# Altium schematic sheet controller_peripherals.SchDoc(controller_peripherals)
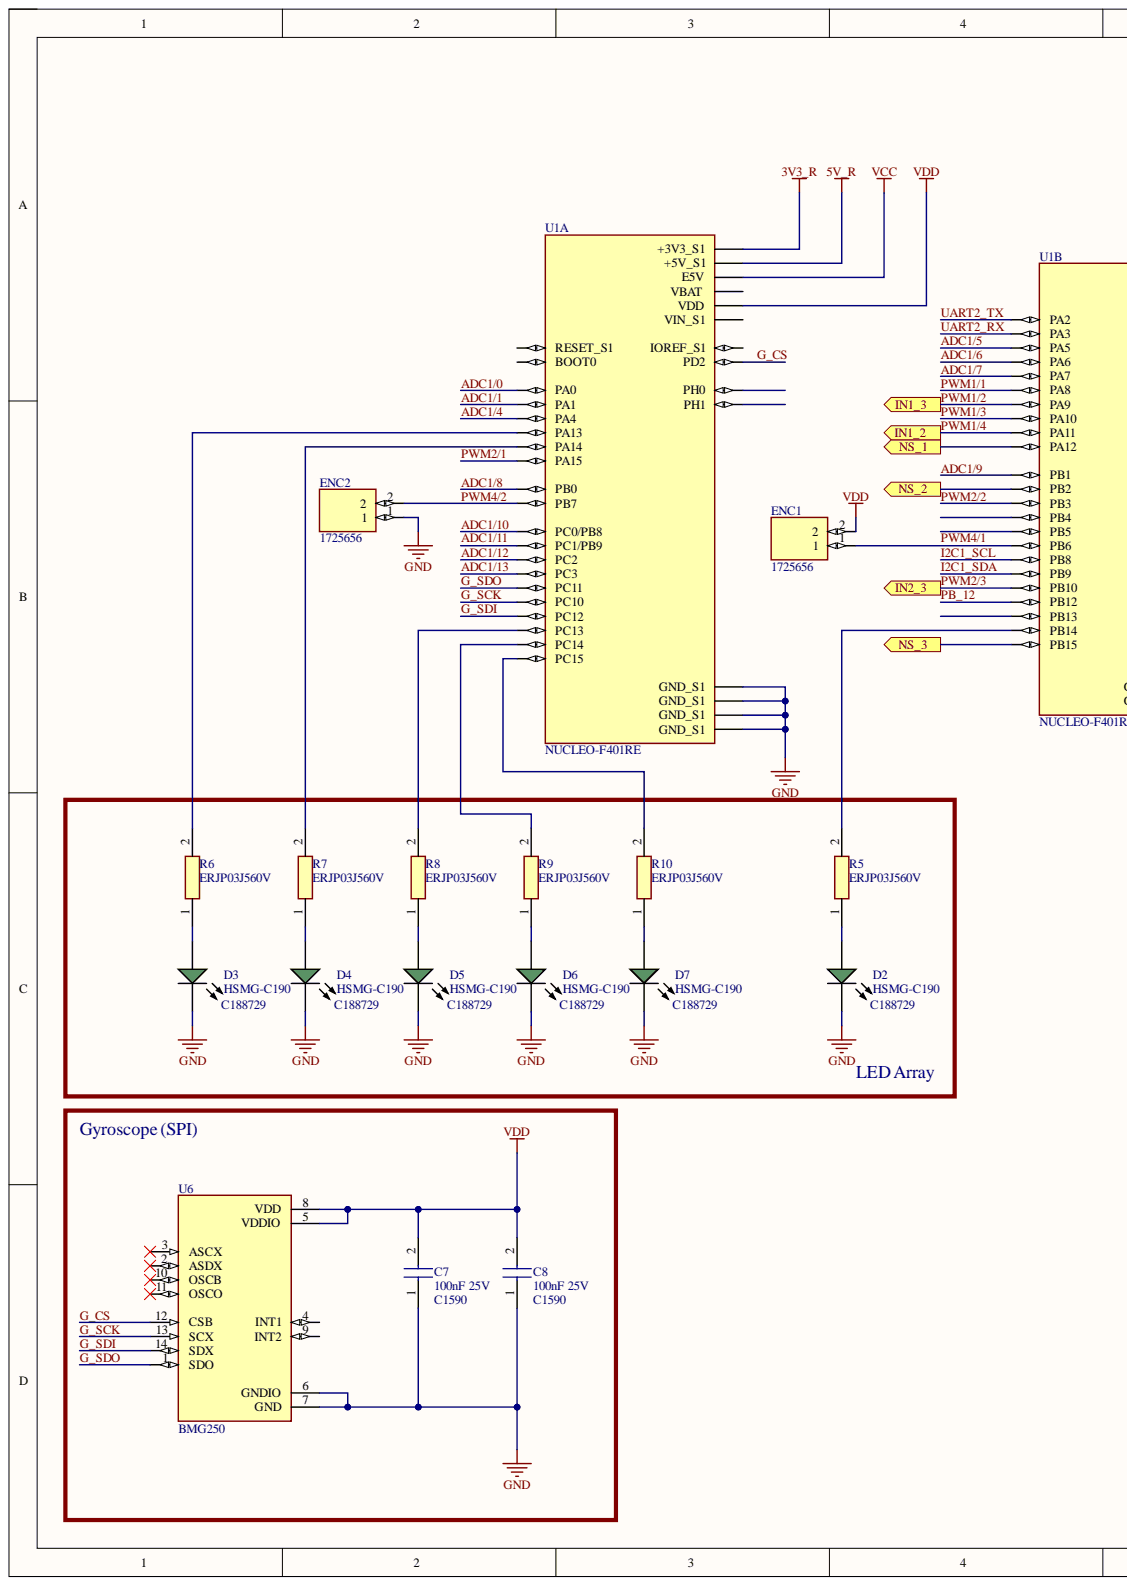
[diagram p0: controller_peripherals.SchDoc, left]
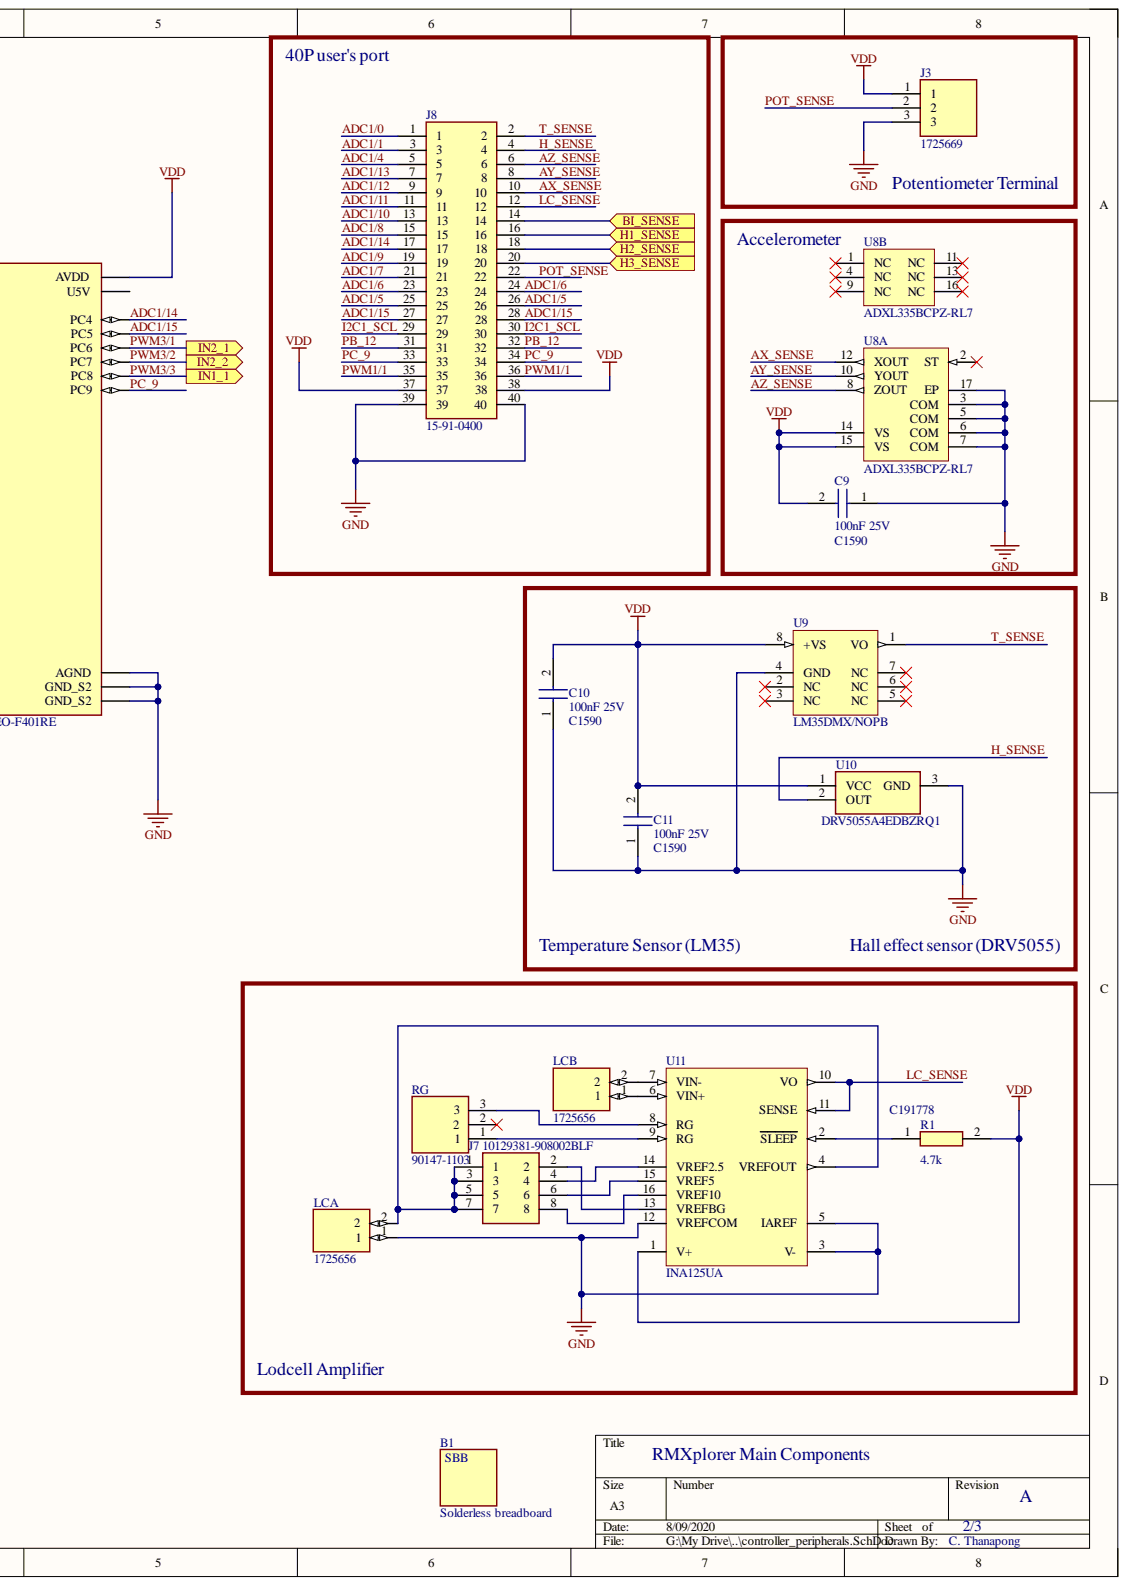
[diagram p1: controller_peripherals.SchDoc, right]

## Parts
B1  Solderless breadboard  [Solderless Breadboard]
C7  100nF 25V  Supplier Part Number 1: C1590  [CAPC1608X90N]
C8  100nF 25V  Supplier Part Number 1: C1590  [CAPC1608X90N]
C9  100nF 25V  Supplier Part Number 1: C1590  [CAPC1608X90N]
C10  100nF 25V  Supplier Part Number 1: C1590  [CAPC1608X90N]
C11  100nF 25V  Supplier Part Number 1: C1590  [CAPC1608X90N]
D2  HSMG-C190  Supplier Part Number 2: C188729  [AVAG-HSMX-C190_V]
D3  HSMG-C190  Supplier Part Number 2: C188729  [AVAG-HSMX-C190_V]
D4  HSMG-C190  Supplier Part Number 2: C188729  [AVAG-HSMX-C190_V]
D5  HSMG-C190  Supplier Part Number 2: C188729  [AVAG-HSMX-C190_V]
D6  HSMG-C190  Supplier Part Number 2: C188729  [AVAG-HSMX-C190_V]
D7  HSMG-C190  Supplier Part Number 2: C188729  [AVAG-HSMX-C190_V]
ENC1  1725656  [1725656]
ENC2  1725656  [1725656]
J3  1725669  [1725669]
J7  10129381-908002BLF  [HDRV8W67P254_2X4_1016X483X858P]
J8  15-91-0400  [15910400]
LCA  1725656  [1725656]
LCB  1725656  [1725656]
R1  4.7k  Supplier Part Number 1: C191778  [RESC1608X55N]
R5  ERJP03J560V  [RESC1609X55N]
R6  ERJP03J560V  [RESC1609X55N]
R7  ERJP03J560V  [RESC1609X55N]
R8  ERJP03J560V  [RESC1609X55N]
R9  ERJP03J560V  [RESC1609X55N]
R10  ERJP03J560V  [RESC1609X55N]
RG  90147-1103  [SHDR3W70P0X254_1X3_747X244X870P]
U1  NUCLEO-F401RE  [ST_NUCLEO-F401RE]  (parts A,B)
U6  BMG250  [XDCR_BMG250]
U8  ADXL335BCPZ-RL7  [ADI-CP-16-14_L]  (parts A,B)
U9  LM35DMX/NOPB  [D0008A_L]
U10  DRV5055A4EDBZRQ1  [SOT95P237X112-3N]
U11  INA125UA  [D0016A_N]

## Ports
BI_SENSE, H1_SENSE, H2_SENSE, H3_SENSE, IN1_1, IN1_2, IN1_3, IN2_1, IN2_2, IN2_3, NS_1, NS_2, NS_3

## Nets
3V3_R: U1.CN7_16(+3V3_S1)
5V_R: U1.CN7_18(+5V_S1)
GND: C7.1 C8.1 C9.1 C10.1 C11.1 D2.2 D3.2 D4.2 D5.2 D6.2 D7.2 ENC2.1 J3.3 J8.39 J8.40 LCA.1 U1.CN7_8(GND_S1) U1.CN7_19(GND_S1) U1.CN7_20(GND_S1) U1.CN7_22(GND_S1) U1.CN10_9(GND_S2) U1.CN10_20(GND_S2) U1.CN10_32(AGND) U6.6(GNDIO) U6.7(GND) U8.3(COM) U8.5(COM) U8.6(COM) U8.7(COM) U8.17(EP) U9.4(GND) U10.3 U11.3(V-) U11.5(IAREF) U11.12(VREFCOM)
VCC: U1.CN7_6(E5V)
VDD: C7.2 C8.2 C9.2 C10.2 C11.2 ENC1.2 J3.1 J8.37 J8.38 R1.2 U1.CN7_5(VDD) U1.CN10_7(AVDD) U6.5(VDDIO) U6.8(VDD) U8.14(VS) U8.15(VS) U9.8(+VS) U10.1 U11.1(V+)
ADC1/0: J8.1 U1.CN7_28(PA0)
ADC1/1: J8.3 U1.CN7_30(PA1)
ADC1/4: J8.5 U1.CN7_32(PA4)
ADC1/5: J8.25 J8.26 U1.CN10_11(PA5)
ADC1/6: J8.23 J8.24 U1.CN10_13(PA6)
ADC1/7: J8.21 U1.CN10_15(PA7)
ADC1/8: J8.15 U1.CN7_34(PB0)
ADC1/9: J8.19 U1.CN10_24(PB1)
ADC1/10: J8.13 U1.CN7_38(PC0/PB8)
ADC1/11: J8.11 U1.CN7_36(PC1/PB9)
ADC1/12: J8.9 U1.CN7_35(PC2)
ADC1/13: J8.7 U1.CN7_37(PC3)
ADC1/14: J8.17 U1.CN10_34(PC4)
ADC1/15: J8.27 J8.28 U1.CN10_6(PC5)
AX_SENSE: J8.10 U8.12(XOUT)
AY_SENSE: J8.8 U8.10(YOUT)
AZ_SENSE: J8.6 U8.8(ZOUT)
BI_SENSE: J8.14 ; port BI_SENSE
G_CS: U1.CN7_4(PD2) U6.12(CSB)
G_SCK: U1.CN7_1(PC10) U6.13(SCX)
G_SDI: U1.CN7_3(PC12) U6.14(SDX)
G_SDO: U1.CN7_2(PC11) U6.1(SDO)
H1_SENSE: J8.16 ; port H1_SENSE
H2_SENSE: J8.18 ; port H2_SENSE
H3_SENSE: J8.20 ; port H3_SENSE
H_SENSE: J8.4 U10.2
I2C1_SCL: J8.29 J8.30 U1.CN10_3(PB8)
I2C1_SDA: U1.CN10_5(PB9)
LC_SENSE: J8.12 U11.10(VO) U11.11(SENSE)
NS_1: U1.CN10_12(PA12) ; port NS_1
NS_2: U1.CN10_22(PB2) ; port NS_2
NS_3: U1.CN10_26(PB15) ; port NS_3
PB_12: J8.31 J8.32 U1.CN10_16(PB12)
PC_9: J8.33 J8.34 U1.CN10_1(PC9)
POT_SENSE: J3.2 J8.22
PWM1/1: J8.35 J8.36 U1.CN10_23(PA8)
PWM1/2: U1.CN10_21(PA9) ; port IN1_3
PWM1/3: U1.CN10_33(PA10)
PWM1/4: U1.CN10_14(PA11) ; port IN1_2
PWM2/1: U1.CN7_17(PA15)
PWM2/2: U1.CN10_31(PB3)
PWM2/3: U1.CN10_25(PB10) ; port IN2_3
PWM3/1: U1.CN10_4(PC6) ; port IN2_1
PWM3/2: U1.CN10_19(PC7) ; port IN2_2
PWM3/3: U1.CN10_2(PC8) ; port IN1_1
PWM4/1: ENC1.1 U1.CN10_17(PB6)
PWM4/2: ENC2.2 U1.CN7_21(PB7)
T_SENSE: J8.2 U9.1(VO)
UART2_RX: U1.CN10_37(PA3)
UART2_TX: U1.CN10_35(PA2)
NetD2_1: D2.1 R5.1
NetD3_1: D3.1 R6.1
NetD4_1: D4.1 R7.1
NetD5_1: D5.1 R8.1
NetD6_1: D6.1 R9.1
NetD7_1: D7.1 R10.1
NetJ7_1: J7.1 J7.3 J7.5 J7.7 LCA.2 U11.4(VREFOUT)
NetJ7_2: J7.2 U11.13(VREFBG)
NetJ7_4: J7.4 U11.14(VREF2.5)
NetJ7_6: J7.6 U11.15(VREF5)
NetJ7_8: J7.8 U11.16(VREF10)
NetLCB_1: LCB.1 U11.6(VIN+)
NetLCB_2: LCB.2 U11.7(VIN-)
NetR1_1: R1.1 U11.2(SLEEP)
NetR5_2: R5.2 U1.CN10_28(PB14)
NetR6_2: R6.2 U1.CN7_13(PA13)
NetR7_2: R7.2 U1.CN7_15(PA14)
NetR8_2: R8.2 U1.CN7_23(PC13)
NetR9_2: R9.2 U1.CN7_25(PC14)
NetR10_2: R10.2 U1.CN7_27(PC15)
NetRG_1: RG.1 U11.9(RG)
NetRG_3: RG.3 U11.8(RG)

Not connected: RG 2; U1 CN7_7(BOOT0) CN7_12(IOREF_S1) CN7_14(RESET_S1) CN7_24(VIN_S1) CN7_29(PH0) CN7_31(PH1) CN7_33(VBAT) CN10_8(U5V) CN10_27(PB4) CN10_29(PB5) CN10_30(PB13); U6 2(ASDX) 3(ASCX) 4(INT1) 9(INT2) 10(OSCB) 11(OSCO); U8 1(NC) 2(ST) 4(NC) 9(NC) 11(NC) 13(NC) 16(NC); U9 2(NC) 3(NC) 5(NC) 6(NC) 7(NC)

## Library data of the parts
C7-C11 = CL10B104KA8NNNC: Capacitor; Manufacturer_Name: Samsung Electro-Mechanics; Arrow Part Number: CL10B104KA8NNNC; Arrow Price/Stock: https://www.arrow.com/en/products/cl10b104ka8nnnc/samsung-electro-mechanics; Component Kind: Standard; Datasheet Link: http://media.digikey.com/pdf/Data%20Sheets/Samsung%20PDFs/CL_Series_MLCC_ds.pdf; Height: 0.9mm; Manufacturer_Part_Number: CL10B104KA8NNNC; Supplier 1: LCSC
D2-D7 = CMP-1744-00002-1: Chip LED, Green, 2.2 V, -40 to 85 degC, 2-Pin SMD, RoHS, Tape and Reel; Access Time: 3500 ns; Case\Package: SOIC; Clock Frequency: 100 KHz; Density: 32 kb; Frequency: 400 KHz; Interface: Serial; Max Supply Voltage: 5.5 V; Min Supply Voltage: 1.7 V; Nominal Supply Current: 2 mA; Component Kind: Standard; ComponentLink1Description: Manufacturer URL; ComponentLink1URL: http://www.avagotech.com/; ComponentLink2Description: Datasheet; ComponentLink2URL: http://docs.avagotech.com/docs/AV02-0551EN; ComponentLink3Description: Package Specification; ComponentLink3URL: http://www.fairchildsemi.com/dwg/MA/MA03D.pdf; DatasheetVersion: Mar-12; Height: 1.5 mm; Length: 5 mm; Library Name: Altium Content Vault; Max Clock Frequency: 100 KHz; Max Operating Temperature: 85 degC; Min Operating Temperature: -40 degC; Mount: Surface Mount; Package Quantity: 3000; PackageDescription: 2-Pin Surface Mount Device, Body 1.6 x 0.8 mm, Height 0.9 mm; PackageReference: HSMX-C190; Packaging: Tape and Reel; Pins: 8; Radiation Hardening: No; REACH SVHC: No SVHC; RoHSCompliant: true; Supplier 1: Mouser; Supplier 2: LCSC; Width: 4 mm
ENC1 ENC2 LCA LCB = 1725656: Connector; Manufacturer_Name: Phoenix Contact; Arrow Part Number: 1725656; Arrow Price/Stock: https://www.arrow.com/en/products/1725656/phoenix-contact; Component Kind: Standard; Datasheet Link: https://www.phoenixcontact.com/online/portal/gb?uri=pxc-oc-itemdetail:pid=1725656&library=gben&tab=1; Height: 8mm; Manufacturer_Part_Number: 1725656; Mouser Part Number: 651-1725656; Mouser Price/Stock: https://www.mouser.co.uk/ProductDetail/Phoenix-Contact/1725656?qs=Ul7CXFMnlWWQeccayYbRmw%3D%3D
J3 = 1725669: Connector; Manufacturer_Name: Phoenix Contact; Arrow Part Number: 1725669; Arrow Price/Stock: https://www.arrow.com/en/products/1725669/phoenix-contact; Component Kind: Standard; Datasheet Link: https://www.phoenixcontact.com/online/portal/gb?uri=pxc-oc-itemdetail:pid=1725669&library=gben&tab=1; Height: 8.5mm; Manufacturer_Part_Number: 1725669; Mouser Part Number: 651-1725669; Mouser Price/Stock: https://www.mouser.co.uk/ProductDetail/Phoenix-Contact/1725669?qs=A%2FKWGUWTCZjmkTL0Oe91ew%3D%3D
J7 = 10129381-908002BLF: Connector; Manufacturer_Name: Amphenol; Arrow Part Number: 10129381-908002BLF; Component Kind: Standard; Datasheet Link: https://cdn.amphenol-icc.com/media/wysiwyg/files/drawing/10129381.pdf; Height: 8.58mm; Manufacturer_Part_Number: 10129381-908002BLF; Mouser Part Number: 649-1012938190802BLF; Mouser Price/Stock: https://www.mouser.co.uk/ProductDetail/Amphenol-FCI/10129381-908002BLF?qs=DXv0QSHKF4wp0EFafi7%252BkA%3D%3D; Supplier 1: Mouser; Supplier Part Number 1: 649-1012938190802BLF
J8 = 15-91-0400: Connector; Manufacturer_Name: Molex; Arrow Part Number: 15-91-0400; Component Kind: Standard; Datasheet Link: https://www.mouser.com/datasheet/2/276/0015910400_PCB_HEADERS-157776.pdf; Height: 8.65mm; Manufacturer_Part_Number: 15-91-0400; Mouser Part Number: 538-15-91-0400; Mouser Price/Stock: https://www.mouser.co.uk/ProductDetail/Molex/15-91-0400?qs=dvxwXVM4mZVyxrzPoXCjQA%3D%3D
R1 = ERJ3RBD4701V: Resistor; Manufacturer_Name: Panasonic; Arrow Part Number: ERJ3RBD4701V; Component Kind: Standard; Datasheet Link: http://docs-europe.electrocomponents.com/webdocs/13ba/0900766b813ba21b.pdf; Height: 0.55mm; Manufacturer_Part_Number: ERJ3RBD4701V; Supplier 1: LCSC
R5-R10 = ERJP03J560V: Resistor; Manufacturer_Name: Panasonic; Arrow Part Number: ERJP03J560V; Component Kind: Standard; Datasheet Link: http://industrial.panasonic.com/cdbs/www-data/pdf/RDA0000/AOA0000C102.pdf; Height: 0.55mm; Manufacturer_Part_Number: ERJP03J560V
RG = 90147-1103: Capacitor; Manufacturer_Name: Molex; Arrow Part Number: 90147-1103; Component Kind: Standard; Datasheet Link: https://www.molex.com/pdm_docs/sd/901471103_sd.pdf; Height: 8.7mm; Manufacturer_Part_Number: 90147-1103; Mouser Part Number: 538-90147-1103; Mouser Price/Stock: https://www.mouser.co.uk/ProductDetail/Molex/90147-1103?qs=zXrbR4Jv0OeHqzvtbqVfQw%3D%3D
U1 = NUCLEO-F401RE: STM32F401RE, mbed-Enabled Development Nucleo-64 STM32F4 ARMÂ® CortexÂ®-M4 MCU 32-Bit Embedded Evaluation Board; MF: STMicroelectronics; Availability: Unavailable; Component Kind: Standard; Mouser-Purchase-URL: https://snapeda.com/shop?store=Mouser&id=329267; MP: NUCLEO-F401RE; Package: None; Price: None; SnapEDA_Link: https://www.snapeda.com/parts/NUCLEO-F401RE/STMicroelectronics/view-part/329267/?ref=snap; Library Name: Schlib_rmx.SchLib
U6 = BMG250: Gyroscope X _Pitch_, Y _Roll_, Z _Yaw_ Â±125, 250, 500, 1000, 2000 25Hz ~ 3200Hz IÂ²C, SPI; MF: Bosch; Availability: Unavailable; Component Kind: Standard; MP: BMG250; Package: LGA-14 Bosch Tools; Price: None; SnapEDA_Link: https://www.snapeda.com/parts/BMG250/Bosch%20Sensortec/view-part/627823/?ref=snap; Library Name: Schlib_rmx.SchLib
U8 = CMP-0885-00044-1: Small, Low Power, 3-Axis +/-3 g Accelerometer with Analog Output, 1.6 KHz BW, Industrial, 16-pin QFN, Reel; Manufacturer: Analog Devices; # of Axes: 3; -3dB Bandwidth: 1.6 KHz; Sensitivity: 300 mV/g; Supply Current: 350 uA; Voltage Supply (V): 1.8 to 3.6; Code_JEDEC: MO-220-WGGD; Component Kind: Standard; ComponentLink1Description: Manufacturer URL; ComponentLink1URL: http://www.analog.com/en/index.html; ComponentLink2Description: Datasheet; ComponentLink2URL: http://www.analog.com/static/imported-files/data_sheets/ADXL335.pdf; ComponentLink3Description: Package Specification; ComponentLink3URL: http:/www.analog.com/static/imported-files/packages/PKG_PDF/LFCSP(CP)/CP-16/CP_16_14.pdf; ComponentLink4Description: Product URL; ComponentLink4URL: http://www.analog.com/en/mems-sensors/mems-inertial-sensors/adxl335/products/product.html; DatasheetVersion: Rev. B; Library Name: Altium Content Vault; Mounting Technology: Surface Mount; Output Type: Analog; PackageDescription: 16-Pin Lead Frame Chip Scale Package, 4 x 4 mm Body, 0.65 mm Pitch; PackageReference: CP-16-14; PackageVersion: 051909-A, 05/2009; Packing: Reel; PartNumber: ADXL335BCPZ-RL7
U9 = CMP-0066-00067-3: Precision Centigrade Temperature Sensor, 8-pin Narrow SOIC, Pb-Free; Manufacturer: Texas Instruments; CompType: Temp Sensor IC; PackageTopMarking: NSZXTT*LM35D*M; PowerWise: N; Code_IPC: SOIC127P600-8; Code_JEDEC: MS-012-AA; Component Kind: Standard; ComponentLink1Description: Datasheet URL; ComponentLink1URL: http://www.ti.com/general/docs/lit/getliterature.tsp?genericPartNumber=LM35&fileType=pdf; Library Name: Altium Content Vault; PackageDescription: SOIC Narrow, 4.9 x 3.9 x 1.45mm, 8 Lead, 1.27mm Pitch; PackageReference: D0008A; PinCount: 8; RoHS: Y
U10 = DRV5055A4EDBZRQ1: Integrated Circuit; Manufacturer_Name: Texas Instruments; Arrow Part Number: DRV5055A4EDBZRQ1; Component Kind: Standard; Datasheet Link: https://www.ti.com/lit/gpn/DRV5055-Q1; Height: 1.12mm; Manufacturer_Part_Number: DRV5055A4EDBZRQ1; Mouser Part Number: 595-DRV5055A4EDBZRQ1; Mouser Price/Stock: https://www.mouser.co.uk/ProductDetail/Texas-Instruments/DRV5055A4EDBZRQ1?qs=%252BEew9%252B0nqrC7PSYwKU3ORw%3D%3D; Library Name: Schlib_rmx.SchLib
U11 = CMP-0944-00017-2: Instrumentation Amplifier with Precision Voltage Reference, -40 to 85 degC, 16-pin SOIC (D16), Green (RoHS & no Sb/Br); Manufacturer: Texas Instruments; CMRR(Min)(dB): 90; Gain(V/V): 4 to 10000; Input Bias Current (+/-)(Max)(nA): 50; Input Offset (+/-)(Max)(uV): 500; Input Offset Drift (+/-)(Max)(uV/Degrees Celsius): 5; Noise at 1kHz(Typ)(nV/rt(Hz)): 38; Non-Linearity (+/-)(Max)(%): 0.01; Number of Channels: 1; Quiescent Current (+/-)(Typ)(mA): 0.46; SubFamily: Single Supply; Vs(Max)(V): 36; Vs(Min)(V): 2.7; Code_JEDEC: MS-012; Component Kind: Standard; ComponentLink1Description: Manufacturer URL; ComponentLink1URL: http://www.ti.com/; ComponentLink2Description: Datasheet; ComponentLink2URL: http://www.ti.com/general/docs/lit/getliterature.tsp?genericPartNumber=INA125&fileType=pdf; ComponentLink3Description: Package Specification; ComponentLink3URL: http://www.ti.com/lit/ml/mpds178g/mpds178g.pdf; DatasheetVersion: SBOS060; Eco-Plan: Green (RoHS & no Sb/Br); Library Name: Altium Content Vault; Mounting Technology: Surface Mount; PackageDescription: 16-Pin Plastic Small Outline, Body 9.9 x 3.9 mm, Pitch 1.27 mm; PackageReference: D0016A; PackageVersion: 4040047-6/M, 06/2011; PartNumber: INA125UA
B1 = Solderless breadboard: Component Kind: Standard; Library Name: Schlib_rmx.SchLib
